# Altium schematic sheet Motor_analog_input.SchDoc
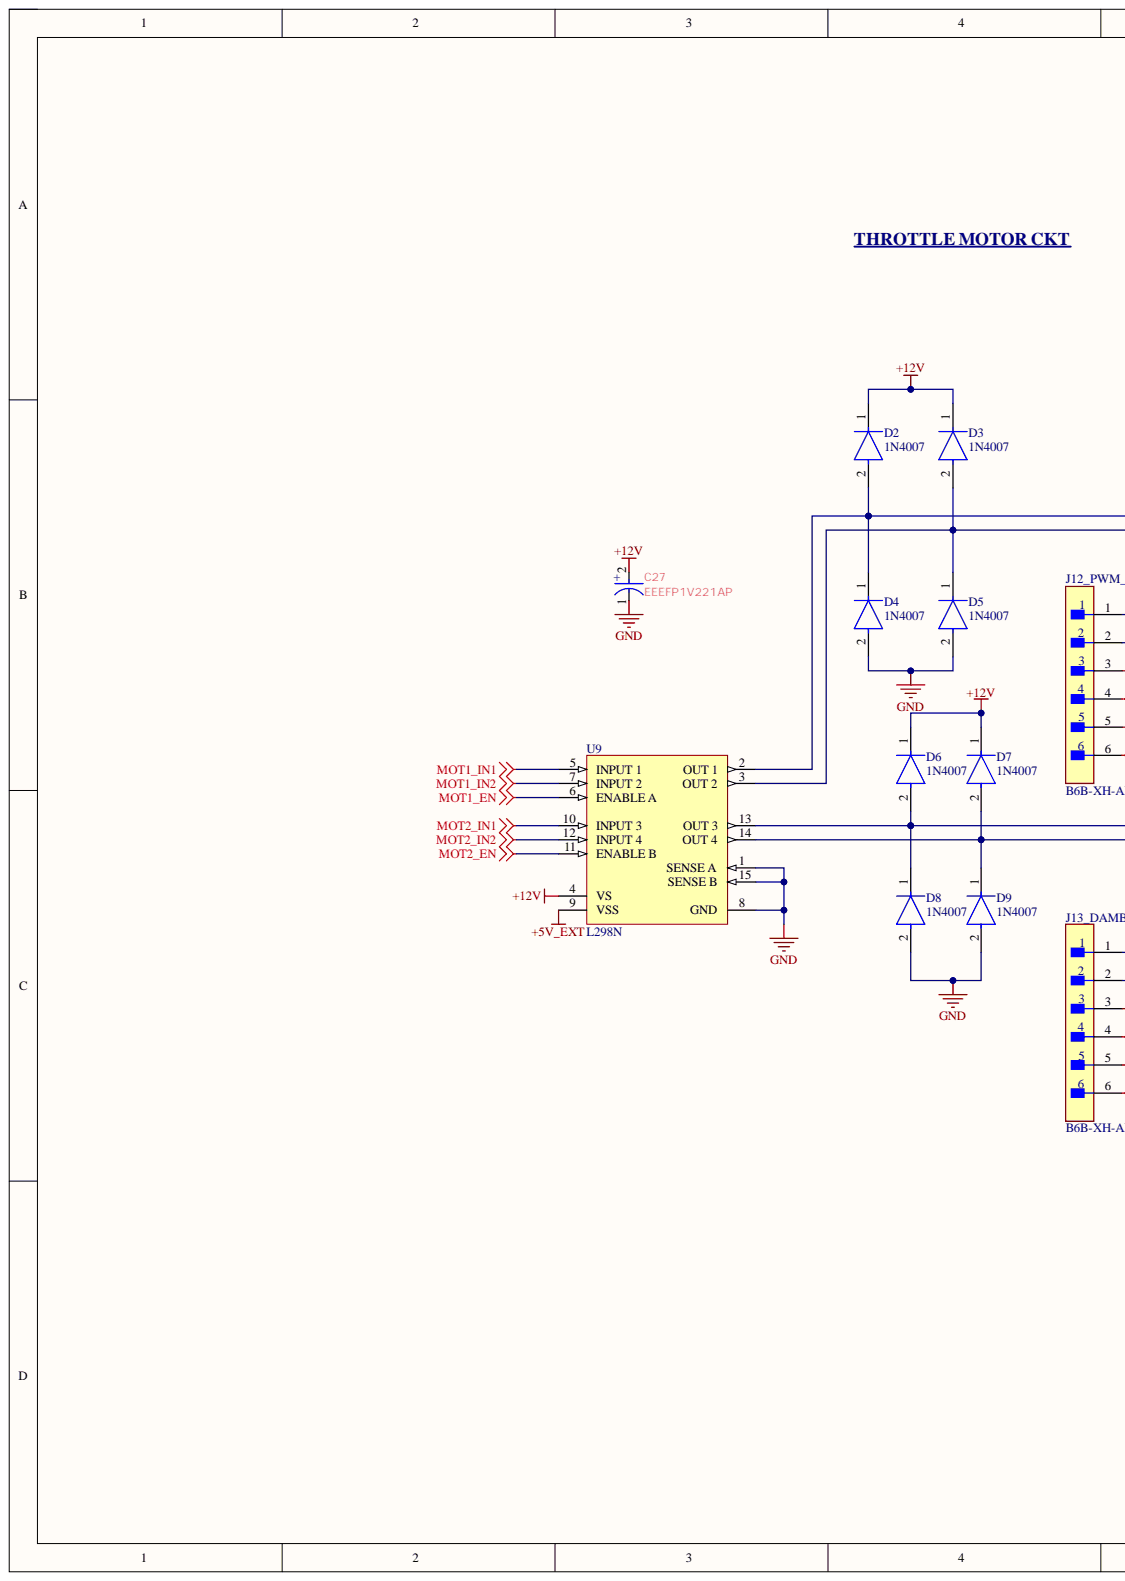
[diagram p0: Motor_analog_input.SchDoc, left]
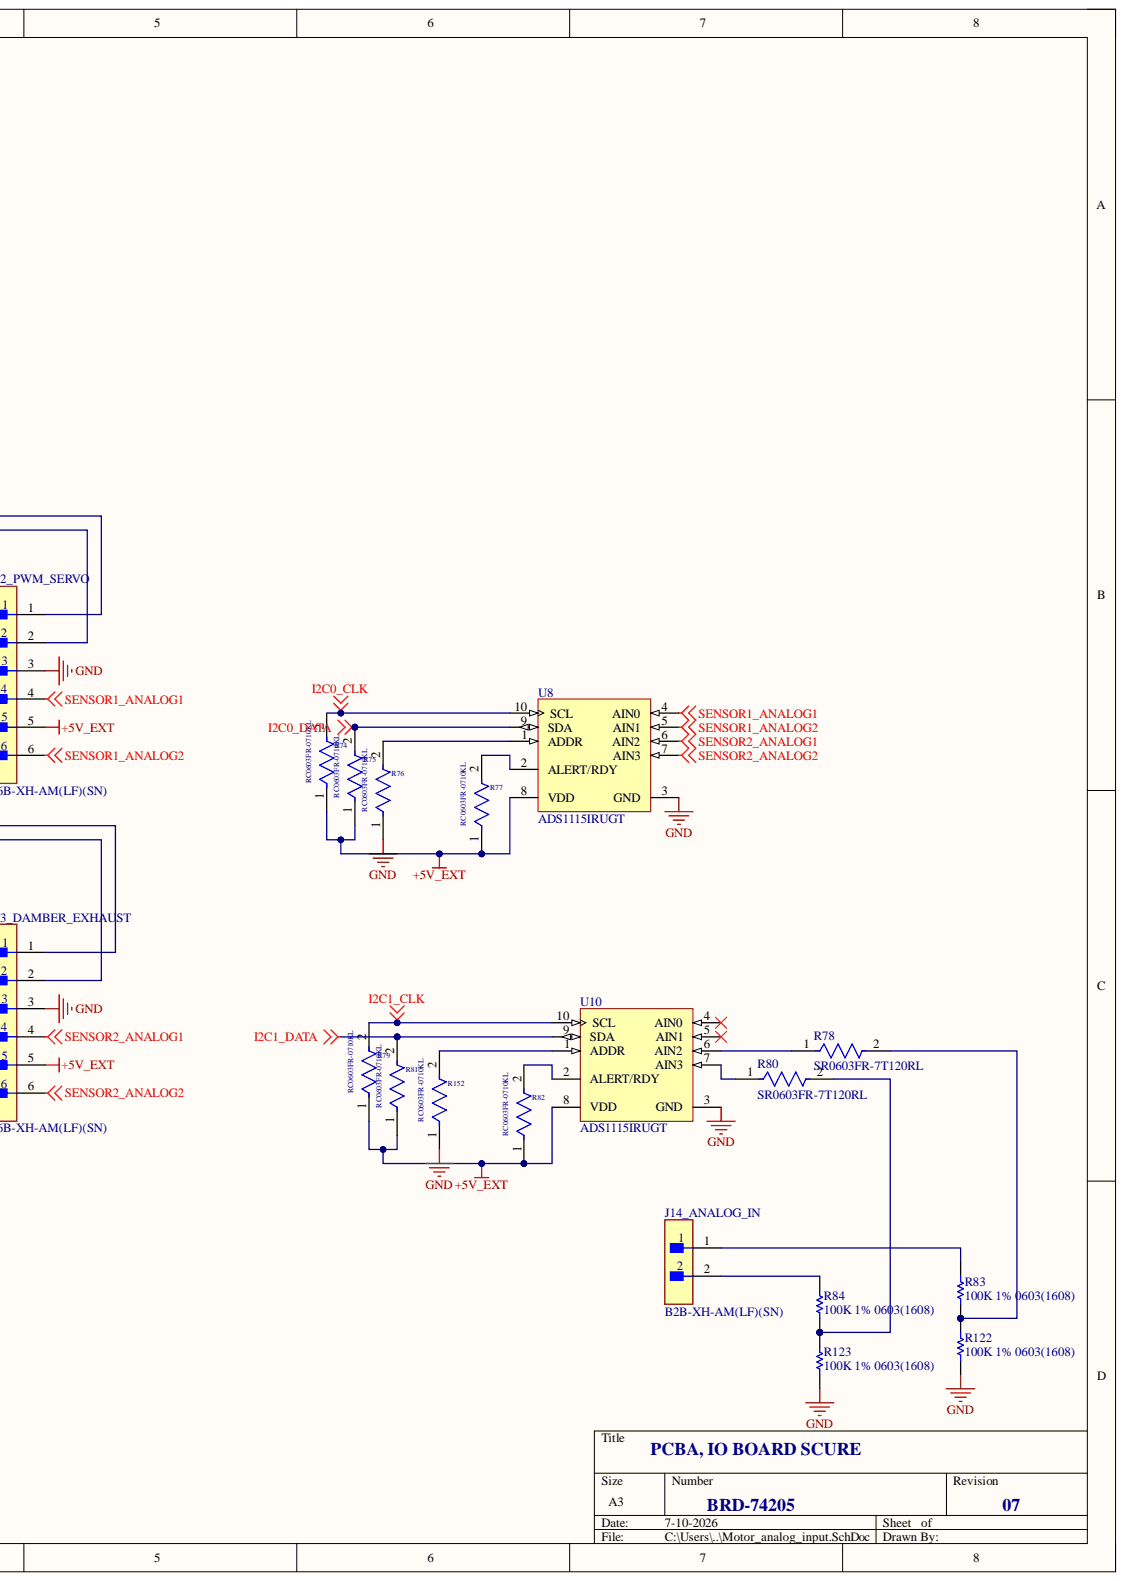
[diagram p1: Motor_analog_input.SchDoc, right]

## Parts
C27  EEEFP1V221AP  [FP-FPF-MFG]
D2  1N4007  [FP-1N4007-MFG]
D3  1N4007  [FP-1N4007-MFG]
D4  1N4007  [FP-1N4007-MFG]
D5  1N4007  [FP-1N4007-MFG]
D6  1N4007  [FP-1N4007-MFG]
D7  1N4007  [FP-1N4007-MFG]
D8  1N4007  [FP-1N4007-MFG]
D9  1N4007  [FP-1N4007-MFG]
J12_PWM_SERVO  B6B-XH-AM(LF)(SN)  [FP-B6B-XH-AM_LF_SN-MFG]
J13_DAMBER_EXHAUST  B6B-XH-AM(LF)(SN)  [FP-B6B-XH-AM_LF_SN-MFG]
J14_ANALOG_IN  B2B-XH-AM(LF)(SN)  [FP-B2B-XH-AM_LF_SN-MFG]
R74  RC0603FR-0710KL  [FP-RC0603-0_55-IPC_C]
R75  RC0603FR-0710KL  [FP-RC0603-0_55-IPC_C]
R76  RC0603FR-0710KL  [FP-RC0603-0_55-IPC_C]
R77  RC0603FR-0710KL  [FP-RC0603-0_55-IPC_C]
R78  SR0603FR-7T120RL  [FP-SR0603-MFG]
R79  RC0603FR-0710KL  [FP-RC0603-0_55-IPC_C]
R80  SR0603FR-7T120RL  [FP-SR0603-MFG]
R81  RC0603FR-0710KL  [FP-RC0603-0_55-IPC_C]
R82  RC0603FR-0710KL  [FP-RC0603-0_55-IPC_C]
R83  100K 1% 0603(1608)  [RESC0603(1608)_L]
R84  100K 1% 0603(1608)  [RESC0603(1608)_L]
R122  100K 1% 0603(1608)  [RESC0603(1608)_L]
R123  100K 1% 0603(1608)  [RESC0603(1608)_L]
R152  RC0603FR-0710KL  [FP-RC0603-0_55-IPC_C]
U8  ADS1115IRUGT  [TI-RUG10_V]
U9  L298N  [STM-FM-15]
U10  ADS1115IRUGT  [TI-RUG10_V]

## Nets
+5V_EXT: J12_PWM_SERVO.5 J13_DAMBER_EXHAUST.5 R74.1 R75.1 R77.1 R79.1 R81.1 R82.1 U8.8(VDD) U9.9(VSS) U10.8(VDD)
+12V: C27.2 D2.1 D3.1 D6.1 D7.1 U9.4(VS)
GND: C27.1 D4.2 D5.2 D8.2 D9.2 J12_PWM_SERVO.3 J13_DAMBER_EXHAUST.3 R76.1 R122.1 R123.1 R152.1 U8.3(GND) U9.1(SENSE_A) U9.8(GND) U9.15(SENSE_B) U10.3(GND)
I2C0_CLK: R74.2 U8.10(SCL)
I2C0_DATA: R75.2 U8.9(SDA)
I2C1_CLK: R79.2 U10.10(SCL)
I2C1_DATA: R81.2 U10.9(SDA)
MOT1_EN: U9.6(ENABLE_A)
MOT1_IN1: U9.5(INPUT_1)
MOT1_IN2: U9.7(INPUT_2)
MOT2_EN: U9.11(ENABLE_B)
MOT2_IN1: U9.10(INPUT_3)
MOT2_IN2: U9.12(INPUT_4)
SENSOR1_ANALOG1: J12_PWM_SERVO.4 U8.4(AIN0)
SENSOR1_ANALOG2: J12_PWM_SERVO.6 U8.5(AIN1)
SENSOR2_ANALOG1: J13_DAMBER_EXHAUST.4 U8.6(AIN2)
SENSOR2_ANALOG2: J13_DAMBER_EXHAUST.6 U8.7(AIN3)
NetD2_2: D2.2 D4.1 J12_PWM_SERVO.1 U9.2(OUT_1)
NetD3_2: D3.2 D5.1 J12_PWM_SERVO.2 U9.3(OUT_2)
NetD6_2: D6.2 D8.1 J13_DAMBER_EXHAUST.1 U9.13(OUT_3)
NetD7_2: D7.2 D9.1 J13_DAMBER_EXHAUST.2 U9.14(OUT_4)
NetJ14_ANALOG_IN_1: J14_ANALOG_IN.1 R83.2
NetJ14_ANALOG_IN_2: J14_ANALOG_IN.2 R84.2
NetR76_2: R76.2 U8.1(ADDR)
NetR77_2: R77.2 U8.2(ALERT/RDY)
NetR78_1: R78.1 U10.6(AIN2)
NetR78_2: R78.2 R83.1 R122.2
NetR80_1: R80.1 U10.7(AIN3)
NetR80_2: R80.2 R84.1 R123.2
NetR82_2: R82.2 U10.2(ALERT/RDY)
NetR152_2: R152.2 U10.1(ADDR)

Not connected: U10 4(AIN0) 5(AIN1)

## Library data of the parts
C27 = CMP-2000-06215-2: CAP ALUM 220UF 20% 35V SMD; ESR (Equivalent Series Resistance): 80mR; Leakage Current: 77uA; Life (Hours): 2000Hour; Material: Aluminium; Polarity: Polar; Ripple Current: 850mA; Capacitance: 220uF; Case/Package: Radial; Component Kind: Standard; Construction: Cylindrical; Contact Plating: Tin; Diameter: 8mm; Height: 10.2mm; Height - Seated (Max): 0.402inch; Lead Free: Lead Free; Lead Pitch: 3.1mm; Length: 10.5mm; Library Name: Altium Content Vault; Max Operating Temperature: 105°C; Min Operating Temperature: -55°C; Mount: Surface Mount; Number of Pins: 2; Package Quantity: 500; Packaging: Tape and Reel; Radiation Hardening: No; REACH SVHC: No SVHC; Ripple Current (AC): 850mA; RoHS Compliant: Yes; Series: FP; Termination: SMD/SMT; Tolerance: 20%; Voltage: 35V; Voltage Rating: 35V; Voltage Rating (DC): 35V; Width: 8.3mm
D2-D9 = CMP-123542-000001-1: Rectifier diode 1000 V 1 A DO-41, ,; Manufacturer: Diotec; Capacitance: 15pF; Forward Current: 1A; Max Junction Temp: +175°C; Max Repetitive Reverse Voltage: 1000V; Max Surge Forward Current: 27A; Peak Reverse Current: 5uA; Value: 15pF; Automotive: No; Category: Diode; Component Kind: Standard; ComponentLink2Description: Datasheet; ComponentLink2URL: https://datasheet.octopart.com/1N4007-Diotec-datasheet-62346875.pdf; Device Class L1: Discrete Semiconductors; Device Class L2: Diodes; Device Class L3: Rectifier Diodes; Height: 2.8mm; Ipc Land Pattern Name: DIOAD888W100L520D280; Lead Free: Yes; Library Name: Altium Content Vault; Manufacturer Part Number: 1N4007; Octopart: https://octopart.com/1n4007-diotec-4040411; Package: 1N4007; Reverse Voltage: 1000V; RoHS: TRUE; Temperature: -50°C to +175°C; Temperature Range High: +175°C; Temperature Range Low: -50°C
J12_PWM_SERVO J13_DAMBER_EXHAUST = CMP-17439-000167-1: CONN HEADER VERT 6POS 2.5MM; Current Rating: 3A; Mated Stacking Heights: 9.8mm; Number of Poles: 6; Shape: Straight; Voltage Rating: 250V; Component Kind: Standard; Connector Type: Header; Contact Finish - Mating: TIN; Contact Material: Brass; Contact Plating: Copper; Contact Shape: Square; Contact Type: Male Pin; Fastening Type: Detent; Flammability Rating: UL94 V-0; Height: 7mm; Insulation Color: Natural; Insulation Material: Nylon; Length: 17.4mm; Library Name: Altium Content Vault; Max Operating Temperature: 85°C; Min Operating Temperature: -25°C; Mount: Through Hole; Number of Pins: 6; Number of Positions: 6; Number of Rows: 1; Packaging: Bulk; Pitch: 2.5mm; Series: XH; Termination: Press-Fit; Width: 5.75mm
J14_ANALOG_IN = CMP-17439-000037-1: CONN HEADER VERT 2POS 2.5MM; Connector Type: Male Pin; Contact Resistance: 20mR; Max Current Rating: 3A; Max Voltage Rating (AC): 250V; Number of Positions: 2; Color: Green; Component Kind: Standard; Contact Material: Brass; Contact Plating: Tin; Contact Type: Male Pin; Depth: 5.75mm; Fastening Type: Detent; Housing Color: White; Housing Material: Polyamide; Lead Free: Lead Free; Length: 7.4mm; Library Name: Altium Content Vault; Max Operating Temperature: 85°C; Min Operating Temperature: -25°C; Mount: Through Hole; Number of Rows: 1; Orientation: Straight; Packaging: Bulk; Pitch: 2.5mm; Series: XH; Termination: Solder
R74-R77 R79 R81 R82 R152 = CMP-2100-03664-2: RES 10K OHM 1% 1/10W 0603; Max Power Dissipation: 100mW; Resistance: 10kR; Temperature Coefficient: 100ppm/°C; Voltage Rating: 75V; Voltage Rating (DC): 50V; Case Code (Imperial): 0603; Case Code (Metric): 1608; Case/Package: 0603; Component Kind: Standard; Construction: Rectangular; Depth: 0.8mm; Features: Moisture Resistant; Height: 0.45mm; Lead Free: Lead Free; Lead Pitch: 0.3mm; Length: 1.6mm; Library Name: Altium Content Vault; Max Operating Temperature: 155°C; Military Standard: Not; Min Operating Temperature: -55°C; Number of Pins: 2; Number of Terminations: 2; Packaging: Tape and Reel; Power Rating: 100mW; Resistance Tolerance: 1%; Resistor Type: Thick Film; RoHS Compliant: Yes; Series: RC; Size Code: 0603; Termination: SMD/SMT; Tolerance: 1%; Weight: 7.1E-05oz; Width: 0.8mm
R78 R80 = CMP-03412-000681-1: RES SMD 0603 1/4W 1% 120 OHM; Manufacturer: Yageo; Automotive Grade: Grade 0; Power Rating: 0.25W; Resistance: 120Ω; Tolerance: 0.01; Value: 120Ω; Voltage Rating: 75V; Automotive: Yes; Category: Res; Component Kind: Standard; ComponentLink2Description: Datasheet; ComponentLink2URL: http://www.yageo.com/documents/recent/PYu-SR_D_F%20tol_RoHS_L_3.pdf; Composition: Thick Film; Device Class L1: Passive Components; Device Class L2: Resistors; Device Class L3: Chip SMD Resistors; Digikey Description: RES SMD 0603 1/4W 1% 120 OHM; Footprint Url: http://www.yageo.com/exep/pages/download/literatures/PYu-R_Mount_10.pdf; Height: 0.55mm; Ipc Land Pattern Name: RESC16080X45; Lead Free: Yes; Library Name: Altium Content Vault; Manufacturer Part Number: SR0603FR-7T120RL; Mouser Description: Surge Chip Resistor Automotive Grade Thick Film 0603 120Ohm 1% Paper T/R; Octopart: https://octopart.com/sr0603fr-7t120rl-yageo-92673849; Package: SR0603; RoHS: TRUE; Series: SR; Temperature: -55°C to +155°C; Temperature Range High: +155°C; Temperature Range Low: -55°C
R83 R84 R122 R123 = CMP-1012-00736-1: 100K 0.1W 1% 0603 (1608 Metric)  SMD; Case-EIA: 0603; Case-Metric: 1608; Value: 100000.00 Ohm; Component Kind: Standard; Library Name: Altium Content Vault; Power: 0.1 W; Technology: SMT; Tolerance: 1 %
U8 U10 = CMP-0003-00971-1: 16-Bit ADC with Integrated Multiplexer, PGA, Comparator, Oscillator, and Reference, -40 to 125 degC, 10-pin HandDrawn (RUG10), Green (RoHS & no Sb/Br); Manufacturer: Texas Instruments; # Input Channels: 4; Analog Voltage AV/DD(Max)(V): 5.5; Analog Voltage AV/DD(Min)(V): 2; Architecture: Delta-Sigma; INL(Max)(+/-LSB): 1; Interface: Serial I2C; Resolution(Bits): 16; Sample Rate (max)(SPS): 860; SubFamily: Precision ADC (<=10MSPS); Code_JEDEC: MO-288; Component Kind: Standard; ComponentLink1Description: Manufacturer URL; ComponentLink1URL: http://www.ti.com/; ComponentLink2Description: Datasheet; ComponentLink2URL: http://www.ti.com/general/docs/lit/getliterature.tsp?genericPartNumber=ADS1115&fileType=pdf; ComponentLink3Description: Package Specification; ComponentLink3URL: http://www.ti.com/litv/pdf/mpqf216a; DatasheetVersion: SBAS444B; Digital Supply(Max)(V): 5.5; Digital Supply(Min)(V): 2; Eco-Plan: Green (RoHS & no Sb/Br); Library Name: Altium Content Vault; Mounting Technology: Surface Mount; PackageDescription: 10-Pin Plastic Quad Flatpack, Body 1.5 x 2 mm, Pitch 0.5 mm; PackageReference: RUG10; PackageVersion: 4208528-3/B, 04/2008; PartNumber: ADS1115IRUGT
U9 = CMP-2000-06526-1: Dual Full-Bridge Driver, 4.8 to 46 V, -40 to 150 degC, 15-Pin FM (MW 15L), RoHS, Tube; Manufacturer: STMicroelectronics; PartNumber: L298; Driver Case Style: Multiwatt; Motor Type: FullBridge; No. of Outputs: 4Outputs; No. of Pins: 15Pins; Output Current: 2A; Output Voltage: 46V; Supply Voltage Max: 7V; Supply Voltage Min: 4.5V; SVHC: NoSVHC(17-Dec-2015); Automotive Qualification Standard: -; Component Kind: Standard; Datasheet URL: https://datasheet.ciiva.com/15109/getdatasheetpartid-50682-15109332.pdf; Datasheet Version: 01/2000; Library Name: Altium Content Vault; Manufacturer URL: http://www.st.com/; Mounting Technology: ThroughHole; MSL: -; Operating Temperature Max: 130°C; Operating Temperature Min: -25°C; Package Description: 15-Pin Flange Mount, Body 5 x 19.6 mm, Pitch 1.27 mm; Package Reference: FM-15; Packaging: Each; Product Range: -
